# Altium schematic sheet Schematic Prints("All Documents",Physical)
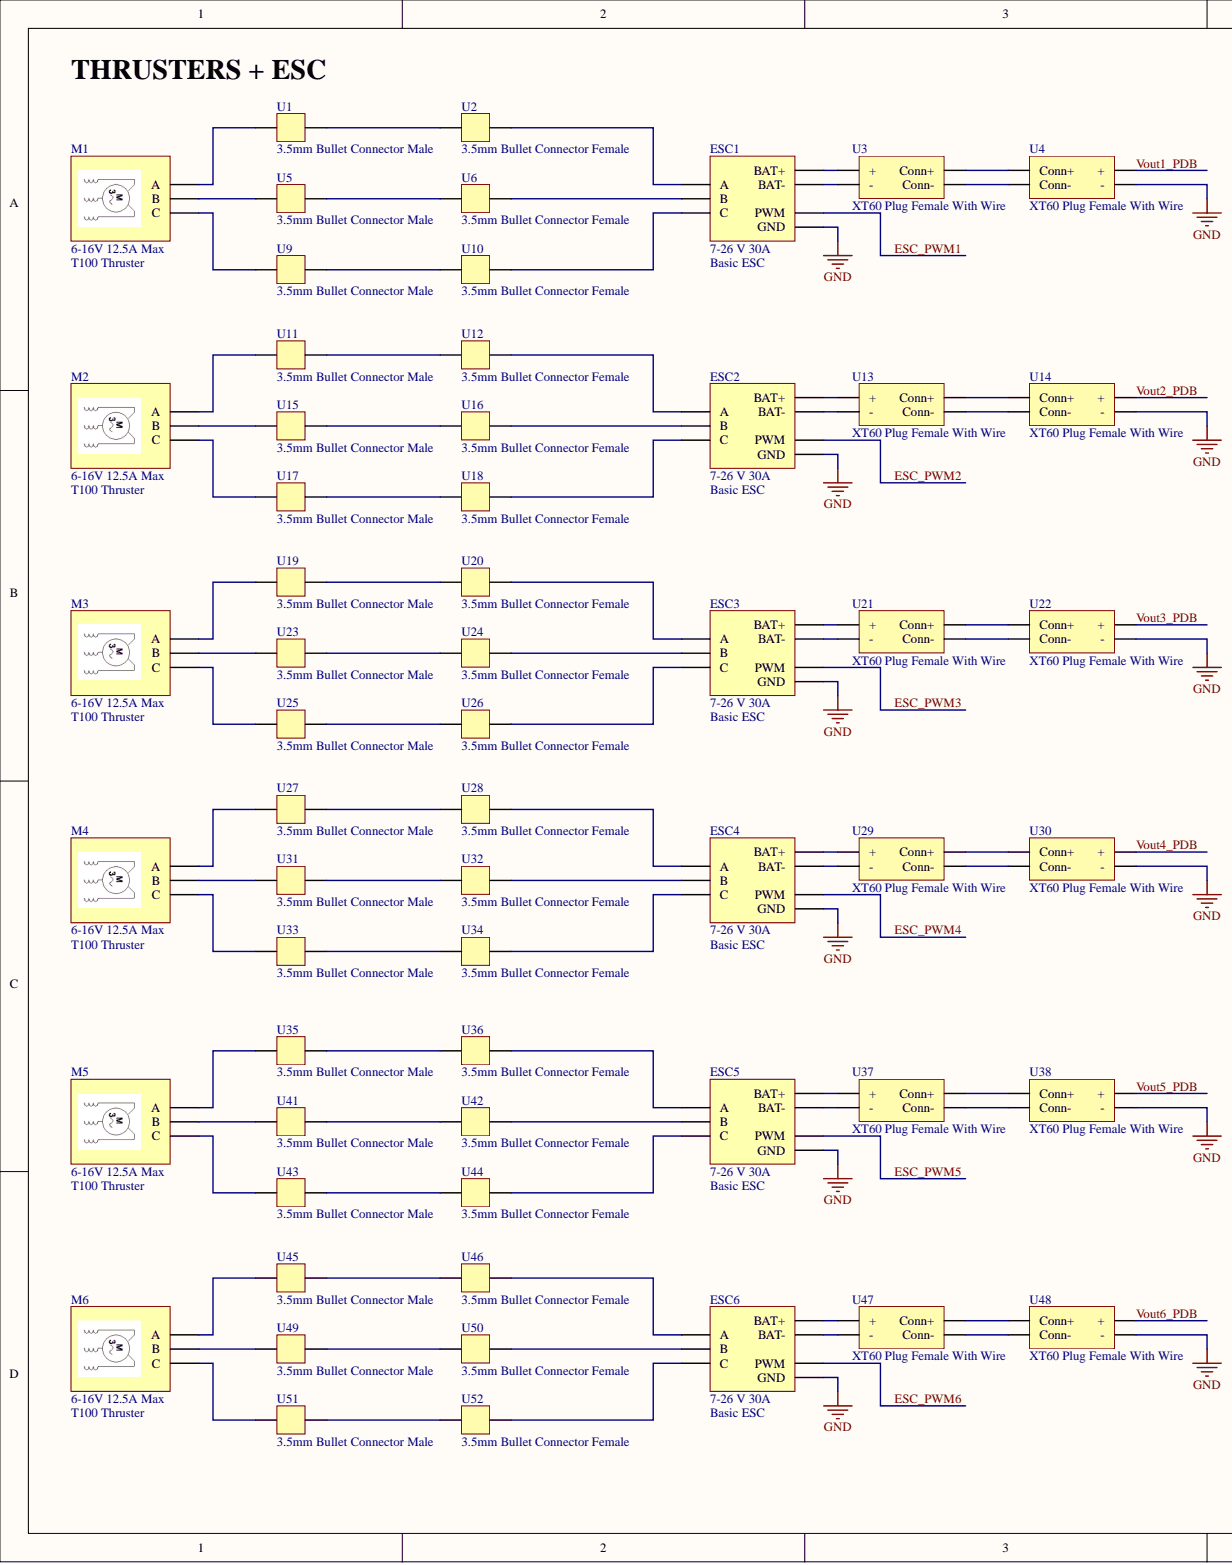
[diagram p0: Schematic Prints("All Documents",Physical), left]
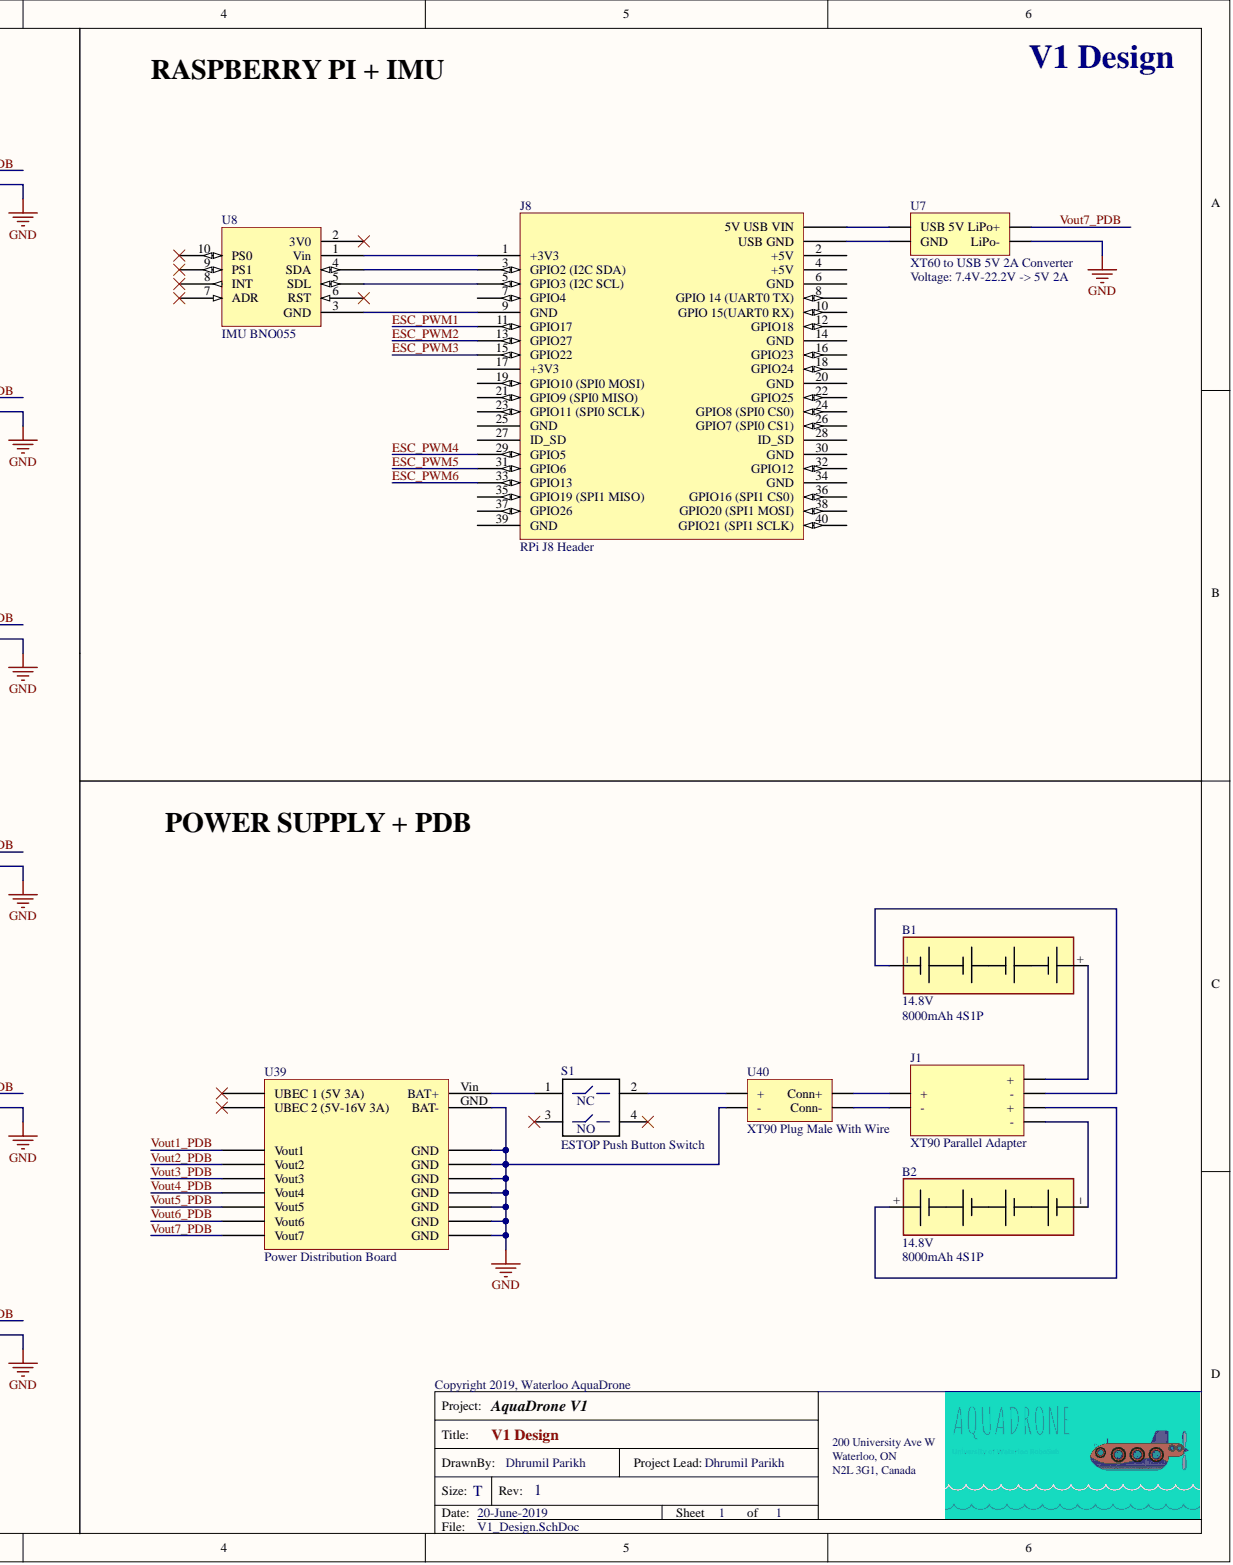
[diagram p1: Schematic Prints("All Documents",Physical), right]

## Parts
B1  value 8000mAh 4S1P  VOLTAGE: 14.8V  (hidden comment: ZIPPY Flightmax 8000mAh 4S1P 30C w/ XT90)
B2  value 8000mAh 4S1P  VOLTAGE: 14.8V  (hidden comment: ZIPPY Flightmax 8000mAh 4S1P 30C w/ XT90)
ESC1  Basic ESC  VOLTAGE: 7-26 V 30A
ESC2  Basic ESC  VOLTAGE: 7-26 V 30A
ESC3  Basic ESC  VOLTAGE: 7-26 V 30A
ESC4  Basic ESC  VOLTAGE: 7-26 V 30A
ESC5  Basic ESC  VOLTAGE: 7-26 V 30A
ESC6  Basic ESC  VOLTAGE: 7-26 V 30A
J1  XT90 Parallel Adapter
J8  value RPi J8 Header  (hidden comment: RASPBERRY PI 3 MODEL B+)
M1  T100 Thruster  VOLTAGE: 6-16V 12.5A Max  MFG_PART_NO: T100
M2  T100 Thruster  VOLTAGE: 6-16V 12.5A Max  MFG_PART_NO: T100
M3  T100 Thruster  VOLTAGE: 6-16V 12.5A Max  MFG_PART_NO: T100
M4  T100 Thruster  VOLTAGE: 6-16V 12.5A Max  MFG_PART_NO: T100
M5  T100 Thruster  VOLTAGE: 6-16V 12.5A Max  MFG_PART_NO: T100
M6  T100 Thruster  VOLTAGE: 6-16V 12.5A Max  MFG_PART_NO: T100
S1  ESTOP Switch  value ESTOP Push Button Switch
U1  value 3.5mm Bullet Connector Male  (hidden comment: 3.5mm Bullet Banana Connector Male)
U2  value 3.5mm Bullet Connector Female  (hidden comment: 3.5mm Bullet Banana Connector Female)
U3  XT60 Plug Female  value XT60 Plug Female With Wire
U4  XT60 Plug Female  value XT60 Plug Female With Wire
U5  value 3.5mm Bullet Connector Male  (hidden comment: 3.5mm Bullet Banana Connector Male)
U6  value 3.5mm Bullet Connector Female  (hidden comment: 3.5mm Bullet Banana Connector Female)
U7  value XT60 to USB 5V 2A Converter  Voltage: 7.4V-22.2V -> 5V 2A  (hidden comment: Lipo Battery XT60 to USB 5V 2A Converter)
U8  value IMU BNO055  MFG_PART_NO: BNO055  (hidden comment: BREAKOUT BOARD 9-DOF IMU BNO055)
U9  value 3.5mm Bullet Connector Male  (hidden comment: 3.5mm Bullet Banana Connector Male)
U10  value 3.5mm Bullet Connector Female  (hidden comment: 3.5mm Bullet Banana Connector Female)
U11  value 3.5mm Bullet Connector Male  (hidden comment: 3.5mm Bullet Banana Connector Male)
U12  value 3.5mm Bullet Connector Female  (hidden comment: 3.5mm Bullet Banana Connector Female)
U13  XT60 Plug Female  value XT60 Plug Female With Wire
U14  XT60 Plug Female  value XT60 Plug Female With Wire
U15  value 3.5mm Bullet Connector Male  (hidden comment: 3.5mm Bullet Banana Connector Male)
U16  value 3.5mm Bullet Connector Female  (hidden comment: 3.5mm Bullet Banana Connector Female)
U17  value 3.5mm Bullet Connector Male  (hidden comment: 3.5mm Bullet Banana Connector Male)
U18  value 3.5mm Bullet Connector Female  (hidden comment: 3.5mm Bullet Banana Connector Female)
U19  value 3.5mm Bullet Connector Male  (hidden comment: 3.5mm Bullet Banana Connector Male)
U20  value 3.5mm Bullet Connector Female  (hidden comment: 3.5mm Bullet Banana Connector Female)
U21  XT60 Plug Female  value XT60 Plug Female With Wire
U22  XT60 Plug Female  value XT60 Plug Female With Wire
U23  value 3.5mm Bullet Connector Male  (hidden comment: 3.5mm Bullet Banana Connector Male)
U24  value 3.5mm Bullet Connector Female  (hidden comment: 3.5mm Bullet Banana Connector Female)
U25  value 3.5mm Bullet Connector Male  (hidden comment: 3.5mm Bullet Banana Connector Male)
U26  value 3.5mm Bullet Connector Female  (hidden comment: 3.5mm Bullet Banana Connector Female)
U27  value 3.5mm Bullet Connector Male  (hidden comment: 3.5mm Bullet Banana Connector Male)
U28  value 3.5mm Bullet Connector Female  (hidden comment: 3.5mm Bullet Banana Connector Female)
U29  XT60 Plug Female  value XT60 Plug Female With Wire
U30  XT60 Plug Female  value XT60 Plug Female With Wire
U31  value 3.5mm Bullet Connector Male  (hidden comment: 3.5mm Bullet Banana Connector Male)
U32  value 3.5mm Bullet Connector Female  (hidden comment: 3.5mm Bullet Banana Connector Female)
U33  value 3.5mm Bullet Connector Male  (hidden comment: 3.5mm Bullet Banana Connector Male)
U34  value 3.5mm Bullet Connector Female  (hidden comment: 3.5mm Bullet Banana Connector Female)
U35  value 3.5mm Bullet Connector Male  (hidden comment: 3.5mm Bullet Banana Connector Male)
U36  value 3.5mm Bullet Connector Female  (hidden comment: 3.5mm Bullet Banana Connector Female)
U37  XT60 Plug Female  value XT60 Plug Female With Wire
U38  XT60 Plug Female  value XT60 Plug Female With Wire
U39  value Power Distribution Board  (hidden comment: PDB + 2 x UBEC)
U40  XT90 Plug Male  value XT90 Plug Male With Wire
U41  value 3.5mm Bullet Connector Male  (hidden comment: 3.5mm Bullet Banana Connector Male)
U42  value 3.5mm Bullet Connector Female  (hidden comment: 3.5mm Bullet Banana Connector Female)
U43  value 3.5mm Bullet Connector Male  (hidden comment: 3.5mm Bullet Banana Connector Male)
U44  value 3.5mm Bullet Connector Female  (hidden comment: 3.5mm Bullet Banana Connector Female)
U45  value 3.5mm Bullet Connector Male  (hidden comment: 3.5mm Bullet Banana Connector Male)
U46  value 3.5mm Bullet Connector Female  (hidden comment: 3.5mm Bullet Banana Connector Female)
U47  XT60 Plug Female  value XT60 Plug Female With Wire
U48  XT60 Plug Female  value XT60 Plug Female With Wire
U49  value 3.5mm Bullet Connector Male  (hidden comment: 3.5mm Bullet Banana Connector Male)
U50  value 3.5mm Bullet Connector Female  (hidden comment: 3.5mm Bullet Banana Connector Female)
U51  value 3.5mm Bullet Connector Male  (hidden comment: 3.5mm Bullet Banana Connector Male)
U52  value 3.5mm Bullet Connector Female  (hidden comment: 3.5mm Bullet Banana Connector Female)

## Nets
GND: ESC1.GND ESC2.GND ESC3.GND ESC4.GND ESC5.GND ESC6.GND U4.- U7.2 U14.- U22.- U30.- U38.- U39.GND U40.- U48.-
NetB1__: B1._ J1.2
NetB2__: B2._ J1.4(-)
NetU1: U1. U2.
NetU5: U5. U6.
NetU9: U9. U10.
NetU11: U11. U12.
NetU15: U15. U16.
NetU17: U17. U18.
NetU19: U19. U20.
NetU23: U23. U24.
NetU25: U25. U26.
NetU27: U27. U28.
NetU31: U31. U32.
NetU33: U33. U34.
NetU35: U35. U36.
NetU41: U41. U42.
NetU43: U43. U44.
NetU45: U45. U46.
NetU49: U49. U50.
NetU51: U51. U52.
ESC_PWM1: ESC1.PWM J8.11(GPIO17)
ESC_PWM2: ESC2.PWM J8.13(GPIO27)
ESC_PWM3: ESC3.PWM J8.15(GPIO22)
ESC_PWM4: ESC4.PWM J8.29(GPIO5)
ESC_PWM5: ESC5.PWM J8.31(GPIO6)
ESC_PWM6: ESC6.PWM J8.33(GPIO13)
Vout1_PDB: U4.+ U39.Vout1
Vout2_PDB: U14.+ U39.Vout2
Vout3_PDB: U22.+ U39.Vout3
Vout4_PDB: U30.+ U39.Vout4
Vout5_PDB: U38.+ U39.Vout5
Vout6_PDB: U39.Vout6 U48.+
Vout7_PDB: U7.1(LiPo+) U39.Vout7
NetB1_+: B1.+ J1.1(+)
NetB2_+: B2.+ J1.3
NetESC1_A: ESC1.A U2.
NetESC1_B: ESC1.B U6.
NetESC1_BAT+: ESC1.BAT+ U3.+
NetESC1_BAT-: ESC1.BAT- U3.-
NetESC1_C: ESC1.C U10.
NetESC2_A: ESC2.A U12.
NetESC2_B: ESC2.B U16.
NetESC2_BAT+: ESC2.BAT+ U13.+
NetESC2_BAT-: ESC2.BAT- U13.-
NetESC2_C: ESC2.C U18.
NetESC3_A: ESC3.A U20.
NetESC3_B: ESC3.B U24.
NetESC3_BAT+: ESC3.BAT+ U21.+
NetESC3_BAT-: ESC3.BAT- U21.-
NetESC3_C: ESC3.C U26.
NetESC4_A: ESC4.A U28.
NetESC4_B: ESC4.B U32.
NetESC4_BAT+: ESC4.BAT+ U29.+
NetESC4_BAT-: ESC4.BAT- U29.-
NetESC4_C: ESC4.C U34.
NetESC5_A: ESC5.A U36.
NetESC5_B: ESC5.B U42.
NetESC5_BAT+: ESC5.BAT+ U37.+
NetESC5_BAT-: ESC5.BAT- U37.-
NetESC5_C: ESC5.C U44.
NetESC6_A: ESC6.A U46.
NetESC6_B: ESC6.B U50.
NetESC6_BAT+: ESC6.BAT+ U47.+
NetESC6_BAT-: ESC6.BAT- U47.-
NetESC6_C: ESC6.C U52.
NetJ1_2: J1.2 U40.Conn-
NetJ1_3: J1.3 U40.Conn+
NetJ8_1: J8.1(+3V3) U8.1(Vin)
NetJ8_2: J8.2(+5V)
NetJ8_3: J8.3(GPIO2_(I2C_SDA)) U8.4(SDA)
NetJ8_4: J8.4(+5V)
NetJ8_5: J8.5(GPIO3_(I2C_SCL)) U8.5(SDL)
NetJ8_5V USB VIN: J8.5V USB VIN U7.3
NetJ8_6: J8.6(GND)
NetJ8_7: J8.7(GPIO4)
NetJ8_8: J8.8(GPIO_14_(UART0_TX))
NetJ8_9: J8.9(GND) U8.3(GND)
NetJ8_10: J8.10(GPIO_15(UART0_RX))
NetJ8_12: J8.12(GPIO18)
NetJ8_14: J8.14(GND)
NetJ8_16: J8.16(GPIO23)
NetJ8_17: J8.17(+3V3)
NetJ8_18: J8.18(GPIO24)
NetJ8_19: J8.19(GPIO10_(SPI0_MOSI))
NetJ8_20: J8.20(GND)
NetJ8_21: J8.21(GPIO9_(SPI0_MISO))
NetJ8_22: J8.22(GPIO25)
NetJ8_23: J8.23(GPIO11_(SPI0_SCLK))
NetJ8_24: J8.24(GPIO8_(SPI0_CS0))
NetJ8_25: J8.25(GND)
NetJ8_26: J8.26(GPIO7_(SPI0_CS1))
NetJ8_27: J8.27(ID_SD)
NetJ8_28: J8.28(ID_SD)
NetJ8_30: J8.30(GND)
NetJ8_32: J8.32(GPIO12)
NetJ8_34: J8.34(GND)
NetJ8_35: J8.35(GPIO19_(SPI1_MISO))
NetJ8_36: J8.36(GPIO16_(SPI1_CS0))
NetJ8_37: J8.37(GPIO26)
NetJ8_38: J8.38(GPIO20_(SPI1_MOSI))
NetJ8_39: J8.39(GND)
NetJ8_40: J8.40(GPIO21_(SPI1_SCLK))
NetJ8_USB GND: J8.USB GND U7.2
NetM1_A: M1.A U1.
NetM1_B: M1.B U5.
NetM1_C: M1.C U9.
NetM2_A: M2.A U11.
NetM2_B: M2.B U15.
NetM2_C: M2.C U17.
NetM3_A: M3.A U19.
NetM3_B: M3.B U23.
NetM3_C: M3.C U25.
NetM4_A: M4.A U27.
NetM4_B: M4.B U31.
NetM4_C: M4.C U33.
NetM5_A: M5.A U35.
NetM5_B: M5.B U41.
NetM5_C: M5.C U43.
NetM6_A: M6.A U45.
NetM6_B: M6.B U49.
NetM6_C: M6.C U51.
NetS1_1: S1.1 U39.Vin(BAT+)
NetS1_2: S1.2 U40.+
NetS1_3: S1.3
NetS1_4: S1.4
NetU3_Conn+: U3.Conn+ U4.Conn+
NetU3_Conn-: U3.Conn- U4.Conn-
NetU8_2: U8.2(3V0)
NetU8_6: U8.6(RST)
NetU8_7: U8.7(ADR)
NetU8_8: U8.8(INT)
NetU8_9: U8.9(PS1)
NetU8_10: U8.10(PS0)
NetU13_Conn+: U13.Conn+ U14.Conn+
NetU13_Conn-: U13.Conn- U14.Conn-
NetU21_Conn+: U21.Conn+ U22.Conn+
NetU21_Conn-: U21.Conn- U22.Conn-
NetU29_Conn+: U29.Conn+ U30.Conn+
NetU29_Conn-: U29.Conn- U30.Conn-
NetU37_Conn+: U37.Conn+ U38.Conn+
NetU37_Conn-: U37.Conn- U38.Conn-
NetU39_UBEC 1 (5V 3A): U39.UBEC 1 (5V 3A)
NetU39_UBEC 2 (5V-16V 3A): U39.UBEC 2 (5V-16V 3A)
NetU47_Conn+: U47.Conn+ U48.Conn+
NetU47_Conn-: U47.Conn- U48.Conn-

## Library data of the parts
B1 B2 = ZIPPY Flightmax 8000mAh 4S1P 30C w/ XT90: MFG_PART_NO: 9067000298; MFG: hobbyking; COST1K: 70; ROHS: Y; Description: ZIPPY Flightmax 8000mAh 4S1P 30C w/ XT90; Component Kind: Standard; Library Name: AquaDrone.SchLib
ESC1-ESC6 = Basic ESC: MFG_PART_NO: BESC30-R3; MFG: Blue Robotics; COST1K: 25; VALUE: Basic ESC; ROHS: Y; Description: Basic ESC; Component Kind: Standard; Library Name: AquaDrone.SchLib
J1 = XT90 Parallel Adapter: MFG_PART_NO: 015000144; MFG: hobbyking; COST1K: 3.56; VALUE: XT90 Parallel Adapter; ROHS: Y; Description: XT90 Parallel Adapter; Component Kind: Standard; Library Name: AquaDrone.SchLib
J8 = RASPBERRY PI 3 MODEL B+: COST1K: 60; ROHS: Y; MFG_PART_NO: RASPBERRY PI 3 MODEL B+; MFG: Raspberry Pi; Description: RASPBERRY PI 3 MODEL B+; Component Kind: Standard; Library Name: AquaDrone.SchLib
M1-M6 = T100 Thruster: MFG: Blue Robotics; COST1K: 119; VALUE: T100 Thruster; ROHS: Y; Description: T100 Thruster; Component Kind: Standard; Library Name: AquaDrone.SchLib
S1 = ESTOP Switch: MFG_PART_NO: a14041700ux0692; MFG: uxell; COST1K: 12; ROHS: Y; Description: ESTOP Switch; Component Kind: Standard; Library Name: AquaDrone.SchLib
U1 U5 U9 U11 U15 U17 U19 U23 U25 U27 U31 U33 U35 U41 U43 U45 U49 U51 = 3.5mm Bullet Banana Connector Male: MFG_PART_NO: B07H3H7Q68; VALUE: 3.5mm Bullet Connector Male; MFG: ROBOJAX; COST1K: 11.50; ROHS: Y; Description: 3.5mm Bullet Banana Connector Male; Component Kind: Standard; Library Name: AquaDrone.SchLib
U2 U6 U10 U12 U16 U18 U20 U24 U26 U28 U32 U34 U36 U42 U44 U46 U50 U52 = 3.5mm Bullet Banana Connector Female: MFG_PART_NO: B07H3H7Q68; VALUE: 3.5mm Bullet Connector Female; MFG: ROBOJAX; COST1K: 11.50; ROHS: Y; Description: 3.5mm Bullet Banana Connector Female; Component Kind: Standard; Library Name: AquaDrone.SchLib
U3 U13 U21 U29 U37 U47 = XT60 Plug Female: MFG_PART_NO: 015000064; VALUE: XT60 Plug Female With Wire; MFG: hobbyking; COST1K: 5.57; ROHS: Y; Description: XT60 Plug Female; Component Kind: Standard; Library Name: AquaDrone.SchLib
U4 U14 U22 U30 U38 U48 = XT60 Plug Female: MFG_PART_NO: 015000064; MFG: hobbyking; COST1K: 5.57; ROHS: Y; Description: XT60 Plug Female; Component Kind: Standard; Library Name: AquaDrone.SchLib
U7 = Lipo Battery XT60 to USB 5V 2A Converter: MFG_PART_NO: 015000144; MFG: LHI; COST1K: 27; ROHS: Y; Description: Lipo Battery XT60 to USB 5V 2A Converter; Component Kind: Standard; Library Name: AquaDrone.SchLib
U8 = BREAKOUT BOARD 9-DOF IMU BNO055: MFG: Adafruit Industries LLC; COST1K: 50; ROHS: Y; Description: BREAKOUT BOARD 9-DOF IMU BNO055; Component Kind: Standard; Library Name: AquaDrone.SchLib
U39 = PDB + 2 x UBEC: MFG_PART_NO: 747000004; MFG: hobbyking; COST1K: 13.75; ROHS: Y; Description: PDB + 2 x UBEC; Component Kind: Standard; Library Name: AquaDrone.SchLib
U40 = XT90 Plug Male: MFG_PART_NO: 015000064; VALUE: XT90 Plug Male With Wire; MFG: hobbyking; COST1K: 7.28; ROHS: Y; Description: XT90 Plug Male; Component Kind: Standard; Library Name: AquaDrone.SchLib
